# Altium schematic sheet Core_ESP32_ATMEGA328P_V1.0.SchDoc(Core_ESP32_ATMEGA328P_V1.0)
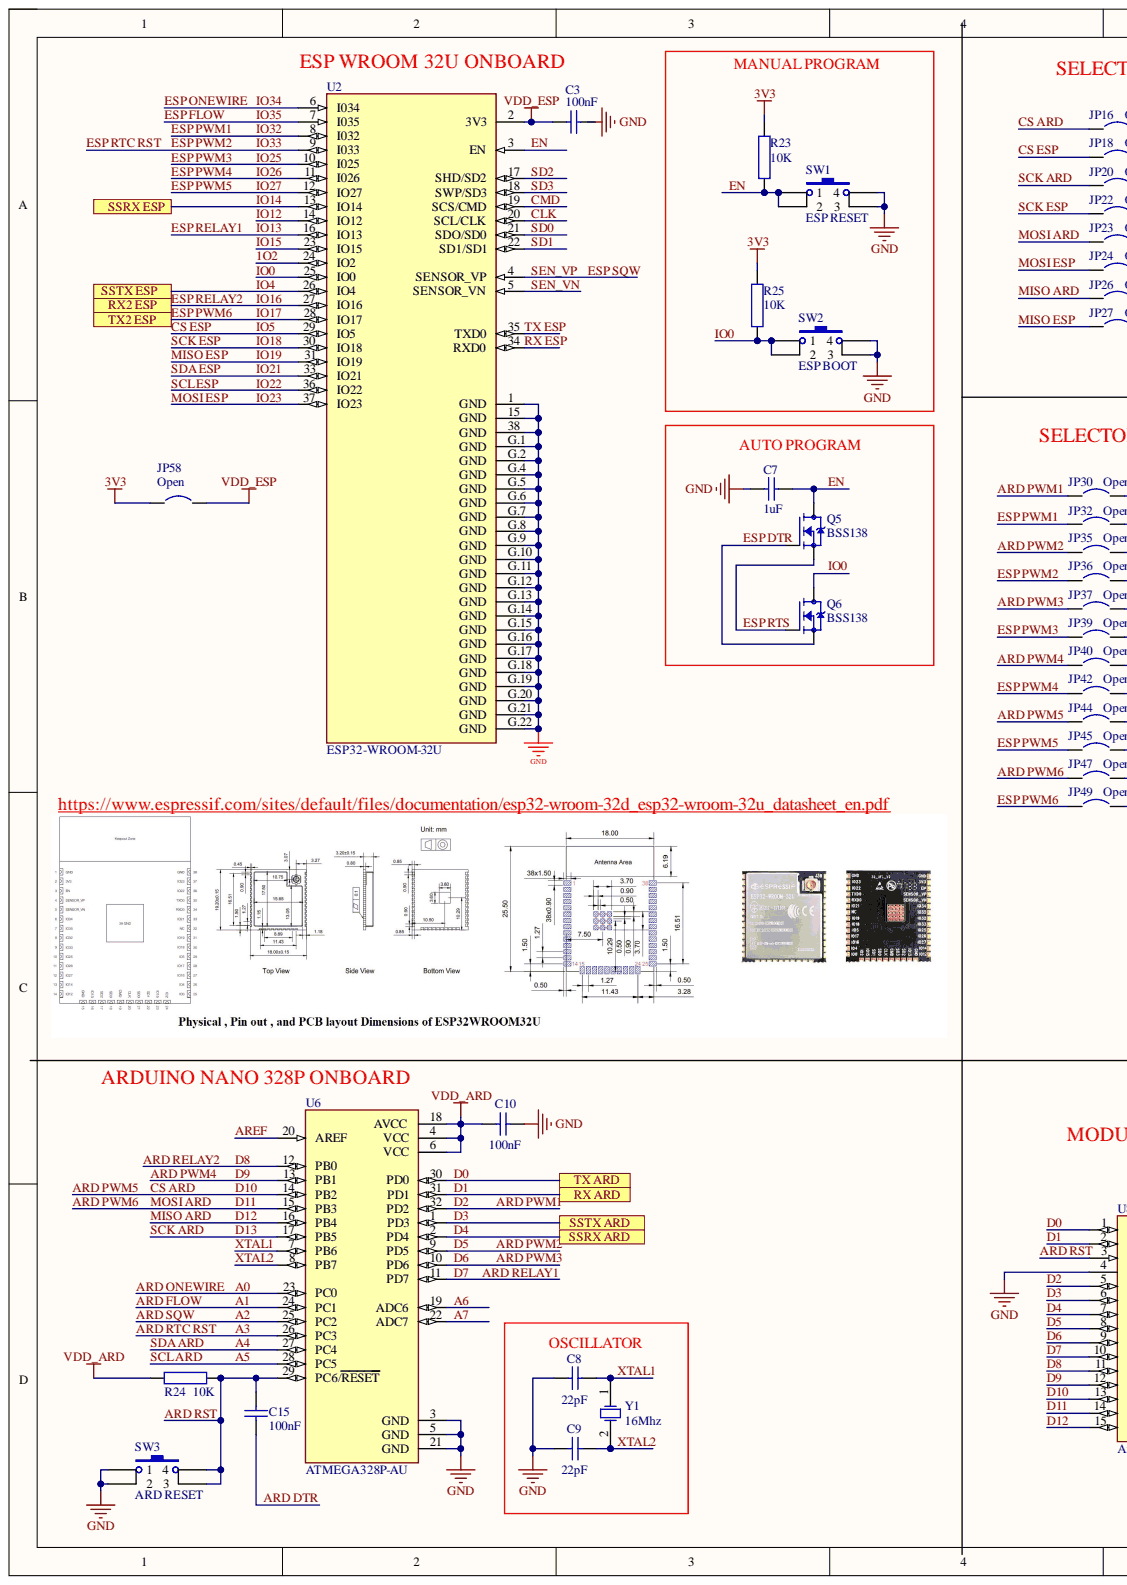
[diagram p0: Core_ESP32_ATMEGA328P_V1.0.SchDoc, left]
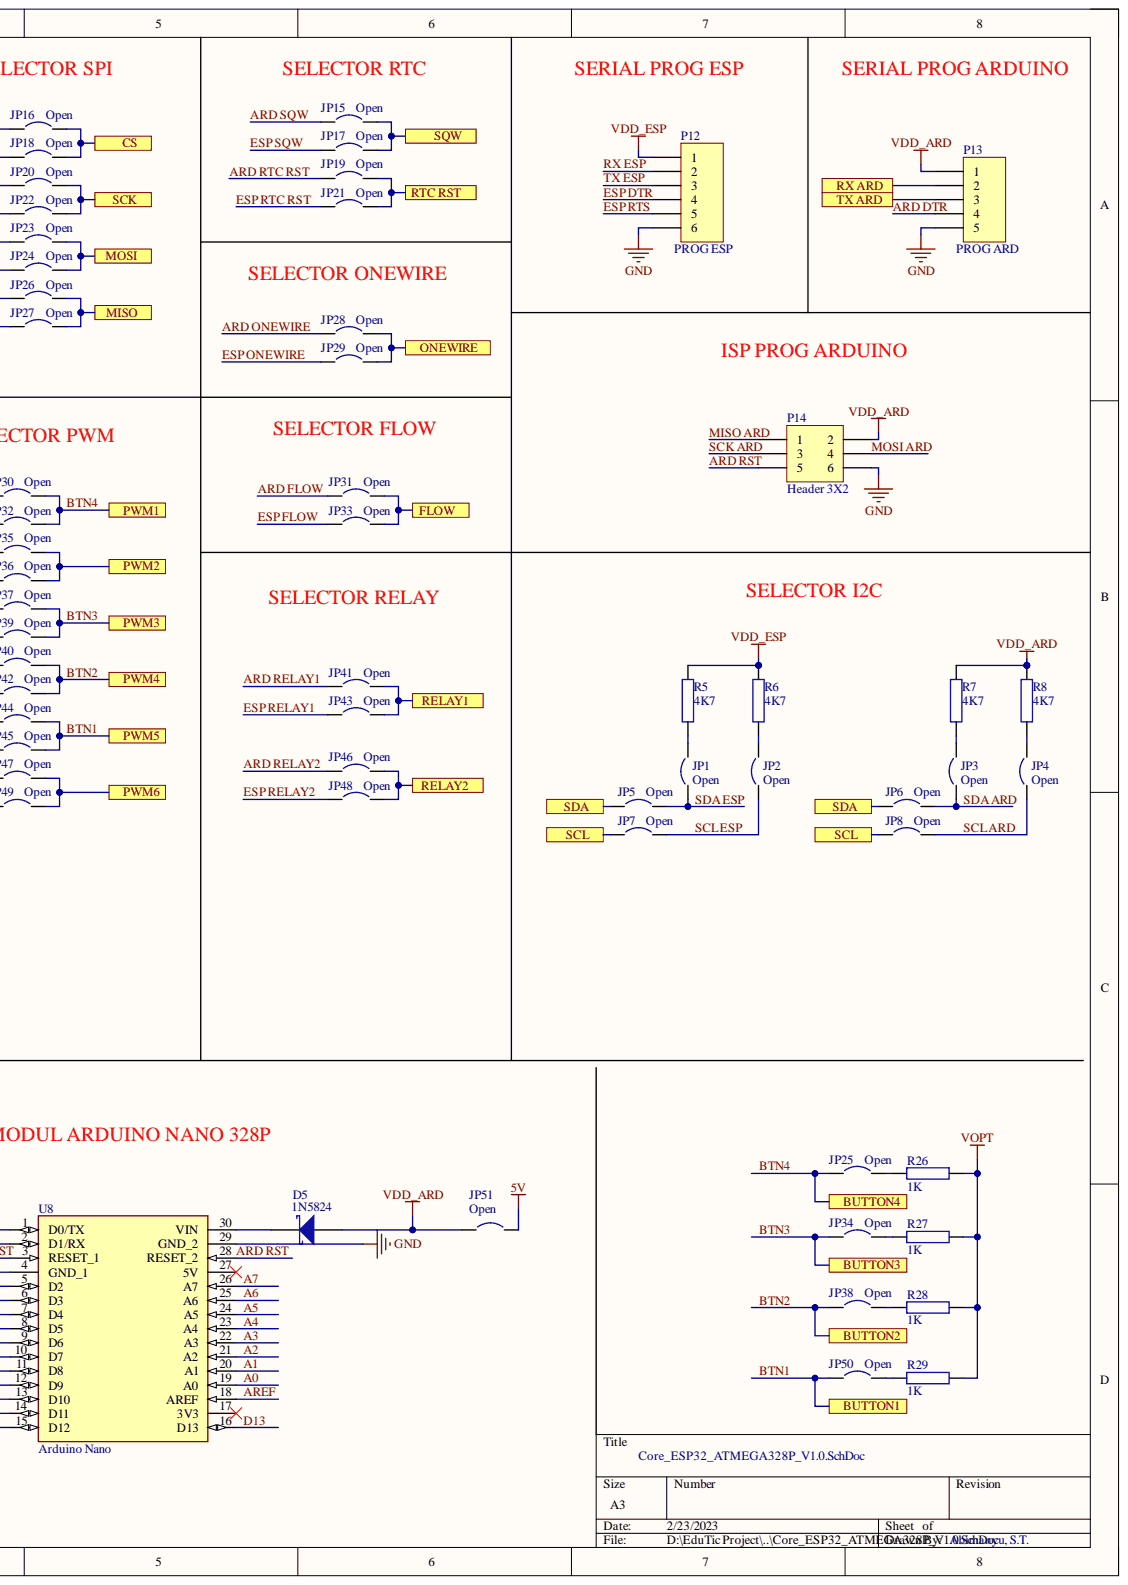
[diagram p1: Core_ESP32_ATMEGA328P_V1.0.SchDoc, right]

## Parts
C3  100nF  [1608_[0603] Capacitor]
C7  1uF  [1608_[0603] Capacitor]
C8  22pF  [1608_[0603] Capacitor]
C9  22pF  [1608_[0603] Capacitor]
C10  100nF  [1608_[0603] Capacitor]
C15  100nF  [1608_[0603] Capacitor]
D5  1N5824  [DO-214AC]
JP1  Open  [SB_STM]
JP2  Open  [SB_STM]
JP3  Open  [SB_STM]
JP4  Open  [SB_STM]
JP5  Open  [SB_STM]
JP6  Open  [SB_STM]
JP7  Open  [SB_STM]
JP8  Open  [SB_STM]
JP15  Open  [SB_STM]
JP16  Open  [SB_STM]
JP17  Open  [SB_STM]
JP18  Open  [SB_STM]
JP19  Open  [SB_STM]
JP20  Open  [SB_STM]
JP21  Open  [SB_STM]
JP22  Open  [SB_STM]
JP23  Open  [SB_STM]
JP24  Open  [SB_STM]
JP25  Open  [SB_STM]
JP26  Open  [SB_STM]
JP27  Open  [SB_STM]
JP28  Open  [SB_STM]
JP29  Open  [SB_STM]
JP30  Open  [SB_STM]
JP31  Open  [SB_STM]
JP32  Open  [SB_STM]
JP33  Open  [SB_STM]
JP34  Open  [SB_STM]
JP35  Open  [SB_STM]
JP36  Open  [SB_STM]
JP37  Open  [SB_STM]
JP38  Open  [SB_STM]
JP39  Open  [SB_STM]
JP40  Open  [SB_STM]
JP41  Open  [SB_STM]
JP42  Open  [SB_STM]
JP43  Open  [SB_STM]
JP44  Open  [SB_STM]
JP45  Open  [SB_STM]
JP46  Open  [SB_STM]
JP47  Open  [SB_STM]
JP48  Open  [SB_STM]
JP49  Open  [SB_STM]
JP50  Open  [SB_STM]
JP51  Open  [SB_STM]
JP58  Open  [SB_STM]
P12  PROG ESP  [Pin Header 1x6 TH Pitch 2.54mm]
P13  PROG ARD  [Pin Header 1x5 TH Pitch 2.54mm]
P14  Header 3X2  [HEADER 2X3]
Q5  BSS138  [SOT-23]
Q6  BSS138  [SOT-23]
R5  4K7  [1608_[0603] Resistor]
R6  4K7  [1608_[0603] Resistor]
R7  4K7  [1608_[0603] Resistor]
R8  4K7  [1608_[0603] Resistor]
R23  10K  [RESISTOR 0603]
R24  10K  [1608_[0603] Resistor]
R25  10K  [RESISTOR 0603]
R26  1K  [RESISTOR 0603]
R27  1K  [RESISTOR 0603]
R28  1K  [RESISTOR 0603]
R29  1K  [RESISTOR 0603]
SW1  ESP RESET  [SW-TACT SMT]
SW2  ESP BOOT  [SW-TACT SMT]
SW3  ARD RESET  [SW-TACT SMT]
U2  ESP32-WROOM-32U  [ESP32-WROOM-32U]
U6  ATMEGA328P-AU  [TQFP-32]
U8  Arduino Nano  MF: Arduino  [ARDUINO NANO]
Y1  16Mhz  [X TAL]

## Ports
BUTTON1, BUTTON2, BUTTON3, BUTTON4, CS, FLOW, MISO, MOSI, ONEWIRE, PWM1, PWM2, PWM3, PWM4, PWM5, PWM6, RELAY1, RELAY2, RTC RST, RX2 ESP, RX ARD, SCK, SCL, SDA, SQW, SSRX ARD, SSRX ESP, SSTX ARD, SSTX ESP, TX2 ESP, TX ARD

## Nets
3V3: JP58.1 R23.2 R25.2
5V: JP51.2
GND: C3.1 C7.1 C8.1 C9.1 C10.2 P12.6 P13.5 P14.6 SW1.3 SW1.4 SW2.3 SW2.4 SW3.1 SW3.2 U2.1(GND) U2.15(GND) U2.38(GND) U2.G.1(GND) U2.G.2(GND) U2.G.4(GND) U2.G.5(GND) U2.G.6(GND) U2.G.7(GND) U2.G.8(GND) U2.G.9(GND) U2.G.10(GND) U2.G.11(GND) U2.G.12(GND) U2.G.13(GND) U2.G.14(GND) U2.G.15(GND) U2.G.16(GND) U2.G.17(GND) U2.G.18(GND) U2.G.19(GND) U2.G.20(GND) U2.G.21(GND) U2.G.22(GND) U6.3(GND) U6.5(GND) U6.21(GND) U8.4(GND_1) U8.29(GND_2)
VDD_ARD: C10.1 D5.A JP51.1 P13.1 P14.2 R7.2 R8.2 R24.2 U6.4(VCC) U6.6(VCC) U6.18(AVCC)
VDD_ESP: C3.2 JP58.2 P12.1 R5.2 R6.2 U2.2(3V3)
VOPT: R26.2 R27.2 R28.2 R29.2
1O2: U2.24(IO2)
A0: JP28.1 U6.23(PC0) U8.19(A0)
A1: JP31.1 U6.24(PC1) U8.20(A1)
A2: JP15.1 U6.25(PC2) U8.21(A2)
A3: JP19.1 U6.26(PC3) U8.22(A3)
A4: JP3.1 JP6.2 U6.27(PC4) U8.23(A4)
A5: JP4.1 JP8.2 U6.28(PC5) U8.24(A5)
A6: U6.19(ADC6) U8.25(A6)
A7: U6.22(ADC7) U8.26(A7)
ARD DTR: C15.1 P13.4
ARD PWM1: JP30.1 U6.32(PD2) U8.5(D2)
ARD PWM2: JP35.1 U6.9(PD5) U8.8(D5)
ARD PWM3: JP37.1 U6.10(PD6) U8.9(D6)
ARD PWM4: JP40.1 U6.13(PB1) U8.12(D9)
ARD PWM5: JP16.1 JP44.1 U6.14(PB2) U8.13(D10)
ARD PWM6: JP23.1 JP47.1 P14.4 U6.15(PB3) U8.14(D11)
ARD RELAY1: JP41.1 U6.11(PD7) U8.10(D7)
ARD RELAY2: JP46.1 U6.12(PB0) U8.11(D8)
ARD RST: C15.2 P14.5 R24.1 SW3.3 SW3.4 U6.29(PC6/RESET) U8.3(RESET_1) U8.28(RESET_2)
AREF: U6.20(AREF) U8.18(AREF)
BTN1: JP44.2 JP45.2 JP50.1 ; port BUTTON1, port PWM5
BTN2: JP38.1 JP40.2 JP42.2 ; port BUTTON2, port PWM4
BTN3: JP34.1 JP37.2 JP39.2 ; port BUTTON3, port PWM3
BTN4: JP25.1 JP30.2 JP32.2 ; port BUTTON4, port PWM1
CLK: U2.20(SCL/CLK)
CMD: U2.19(SCS/CMD)
CS: JP16.2 JP18.2 ; port CS
CS ESP: JP18.1 U2.29(IO5)
D0: P13.3 U6.30(PD0) U8.1(D0/TX) ; port TX ARD
D1: P13.2 U6.31(PD1) U8.2(D1/RX) ; port RX ARD
D3: U6.1(PD3) U8.6(D3) ; port SSTX ARD
D4: U6.2(PD4) U8.7(D4) ; port SSRX ARD
D12: JP26.1 P14.1 U6.16(PB4) U8.15(D12)
D13: JP20.1 P14.3 U6.17(PB5) U8.16(D13)
EN: C7.2 Q5.3 R23.1 SW1.1 SW1.2 U2.3(EN)
ESP DTR: P12.4 Q5.1 Q6.2
ESP FLOW: JP33.1 U2.7(I035)
ESP ONEWIRE: JP29.1 U2.6(I034)
ESP PWM1: JP32.1 U2.8(I032)
ESP PWM2: JP21.1 JP36.1 U2.9(I033)
ESP PWM3: JP39.1 U2.10(I025)
ESP PWM4: JP42.1 U2.11(I026)
ESP PWM5: JP45.1 U2.12(IO27)
ESP PWM6: JP49.1 U2.28(IO17) ; port TX2 ESP
ESP RELAY1: JP43.1 U2.16(IO13)
ESP RELAY2: JP48.1 U2.27(IO16) ; port RX2 ESP
ESP RTS: P12.5 Q5.2 Q6.1
ESP SQW: JP17.1 U2.4(SENSOR_VP)
FLOW: JP31.2 JP33.2 ; port FLOW
IO0: Q6.3 R25.1 SW2.1 SW2.2 U2.25(IO0)
IO4: U2.26(IO4) ; port SSTX ESP
IO12: U2.14(IO12)
IO14: U2.13(IO14) ; port SSRX ESP
IO15: U2.23(IO15)
IO18: JP22.1 U2.30(IO18)
IO19: JP27.1 U2.31(IO19)
IO21: JP1.1 JP5.2 U2.33(IO21)
IO22: JP2.1 JP7.2 U2.36(IO22)
IO23: JP24.1 U2.37(IO23)
MISO: JP26.2 JP27.2 ; port MISO
MOSI: JP23.2 JP24.2 ; port MOSI
ONEWIRE: JP28.2 JP29.2 ; port ONEWIRE
PWM2: JP35.2 JP36.2 ; port PWM2
PWM6: JP47.2 JP49.2 ; port PWM6
RELAY1: JP41.2 JP43.2 ; port RELAY1
RELAY2: JP46.2 JP48.2 ; port RELAY2
RTC RST: JP19.2 JP21.2 ; port RTC RST
RX ESP: P12.2 U2.34(RXD0)
SCK: JP20.2 JP22.2 ; port SCK
SCL: JP7.1 JP8.1 ; port SCL
SD0: U2.21(SDO/SD0)
SD1: U2.22(SD1/SD1)
SD2: U2.17(SHD/SD2)
SD3: U2.18(SWP/SD3)
SDA: JP5.1 JP6.1 ; port SDA
SEN_VN: U2.5(SENSOR_VN)
SQW: JP15.2 JP17.2 ; port SQW
TX ESP: P12.3 U2.35(TXD0)
XTAL1: C8.2 U6.7(PB6) Y1.1
XTAL2: C9.2 U6.8(PB7) Y1.2
NetD5_C: D5.C U8.30(VIN)
NetJP1_2: JP1.2 R5.1
NetJP2_2: JP2.2 R6.1
NetJP3_2: JP3.2 R7.1
NetJP4_2: JP4.2 R8.1
NetJP25_2: JP25.2 R26.1
NetJP34_2: JP34.2 R27.1
NetJP38_2: JP38.2 R28.1
NetJP50_2: JP50.2 R29.1

Not connected: U8 17(3V3) 27(5V)

## Library data of the parts
C3 C7 = Capacitor 0805 (Inch): 0805 SMD Non-Polarity Capacitor; Component Kind: Standard; Library Name: AB_Lib.SchLib
C8-C10 C15 = Capacitor 1206 (Inch): 1206 SMD Non-Polarity Capacitor; Component Kind: Standard; Library Name: AB_Lib.SchLib
D5 = 1N5824 SMD: Maximum repetitive peak reverse voltage 40v  Maximum average forward rectified current 5.0A  Peak forward surge current 8.3 mS 100A  Voltage rate of change 10000 V/uS  Operating junction temperature range -55 to +150 C; MF: Diodes Incorporated; MP: US1M-13; Availability: In Stock; Check_prices: https://www.snapeda.com/parts/US1M-13/Diodes+Inc./view-part/?ref=eda; Component Kind: Standard; Library Name: AB_Lib.SchLib; Package: DO-214AC-214 Diodes Incorporated; Price: None; SnapEDA_Link: https://www.snapeda.com/parts/US1M-13/Diodes+Inc./view-part/?ref=snap
JP1-JP8 JP15-JP51 JP58 = Jumper Solder: Jumper Solder Pad; Component Kind: Standard; Library Name: AB_Lib.SchLib; Published: 24-Mar-1999; Revision: July-2002: Re-released for DXP Platform.; Publisher: Altium Limited
P12 = Header 6  Male: Header, 6-Pin; Component Kind: Standard; LatestRevisionDate: 17-Jul-2002; LatestRevisionNote: Re-released for DXP Platform.; Library Name: AB_Lib.SchLib; Publisher: Altium Limited
P13 = Header 5  Male: Header, 5-Pin; Component Kind: Standard; LatestRevisionDate: 17-Jul-2002; LatestRevisionNote: Re-released for DXP Platform.; Library Name: AB_Lib.SchLib; Publisher: Altium Limited
P14 = Header 3X2: Header, 3-Pin, Dual row; Component Kind: Standard; LatestRevisionDate: 17-Jul-2002; LatestRevisionNote: Re-released for DXP Platform.; Library Name: AB_Lib.SchLib; Publisher: Altium Limited
Q5 Q6 = BSS138: N-CH MOSFET 50V 0.34A SOT-23-3L; MF: Diodes Inc.; Availability: In Stock; Check_prices: https://www.snapeda.com/parts/BSS138/Diodes+Inc./view-part/?ref=eda; Component Kind: Standard; Library Name: AB_Lib.SchLib; MP: BSS138; Package: SOT-23-3 Diodes Inc.; Price: None; Purchase-URL: https://pricing.snapeda.com/search/part/BSS138/?ref=eda; SnapEDA_Link: https://www.snapeda.com/parts/BSS138/Diodes+Inc./view-part/?ref=snap
R5-R8 R24 = Resistor 1206 (Inch): 1206 SMD Thick Resistor; Component Kind: Standard; Library Name: AB_Lib.SchLib
R23 R25 = Resistor 0805 (Inch): 0805 SMD Thick Resistor; Component Kind: Standard; Library Name: AB_Lib.SchLib
R26-R29 = Resistor 0603 (Inch): 0603 SMD Thick Resistor; Component Kind: Standard; Library Name: AB_Lib.SchLib
SW1-SW3 = SW-TACT-SMT: Switch; Component Kind: Standard; LatestRevisionDate: 17-Jul-2002; LatestRevisionNote: Re-released for DXP Platform.; Library Name: AB_Lib.SchLib; PackageDescription: Switch; 2 Leads; PackageReference: SPST-2; Published: 24-Mar-1999; Publisher: Altium Limited
U2 = ESP32-WROOM-32U: Wi-Fi/BT BLE Module ESP32-D0WD SPI Flash UART U.FL Conn.; Availability: Unavailable; Component Kind: Standard; Library Name: AB_Lib.SchLib; MP: ESP32-WROOM-32U; Package: None; Price: None
U6 = ATMEGA328P-AU: AVR AVRÂ® ATmega Microcontroller IC 8-Bit 20MHz 32KB (16K x 16) FLASH 32-TQFP (7x7); MF: Microchip Technology; Availability: In Stock; Check_prices: https://www.snapeda.com/parts/ATMEGA328P-AU/Microchip/view-part/?ref=eda; Component Kind: Standard; Library Name: AB_Lib.SchLib; MP: ATMEGA328P-AU; Package: TQFP-32 Microchip; Price: None; Purchase-URL: https://pricing.snapeda.com/search/part/ATMEGA328P-AU/?ref=eda; SnapEDA_Link: https://www.snapeda.com/parts/ATMEGA328P-AU/Microchip/view-part/?ref=snap
U8 = Arduino Nano: Small, complete, and breadboard-friendly board based on the ATmega328 (Arduino Nano 3.x).; MP: A000005; Availability: In Stock; Check_prices: https://www.snapeda.com/parts/A000005/Arduino/view-part/?ref=eda; Component Kind: Standard; Library Name: AB_Lib.SchLib; Package: Non-Standard Arduino; Price: None; Purchase-URL: https://pricing.snapeda.com/search/part/A000005/?ref=eda; SnapEDA_Link: https://www.snapeda.com/parts/A000005/Arduino/view-part/?ref=snap
Y1 = XTAL: Crystal Oscillator; Component Kind: Standard; LatestRevisionDate: 17-Jul-2002; LatestRevisionNote: Re-released for DXP Platform.; Library Name: AB_Lib.SchLib; PackageDescription: Crystal, Thru-Hole; 2 Leads; Body 3.1 x 8.2 mm (Dia.xH); PackageReference: R38; Published: 8-Jun-2000; Publisher: Altium Limited
